# Altium schematic sheet Sch5 - Fonte 8.8V.SchDoc("Sch5 - Fonte 8.8V")
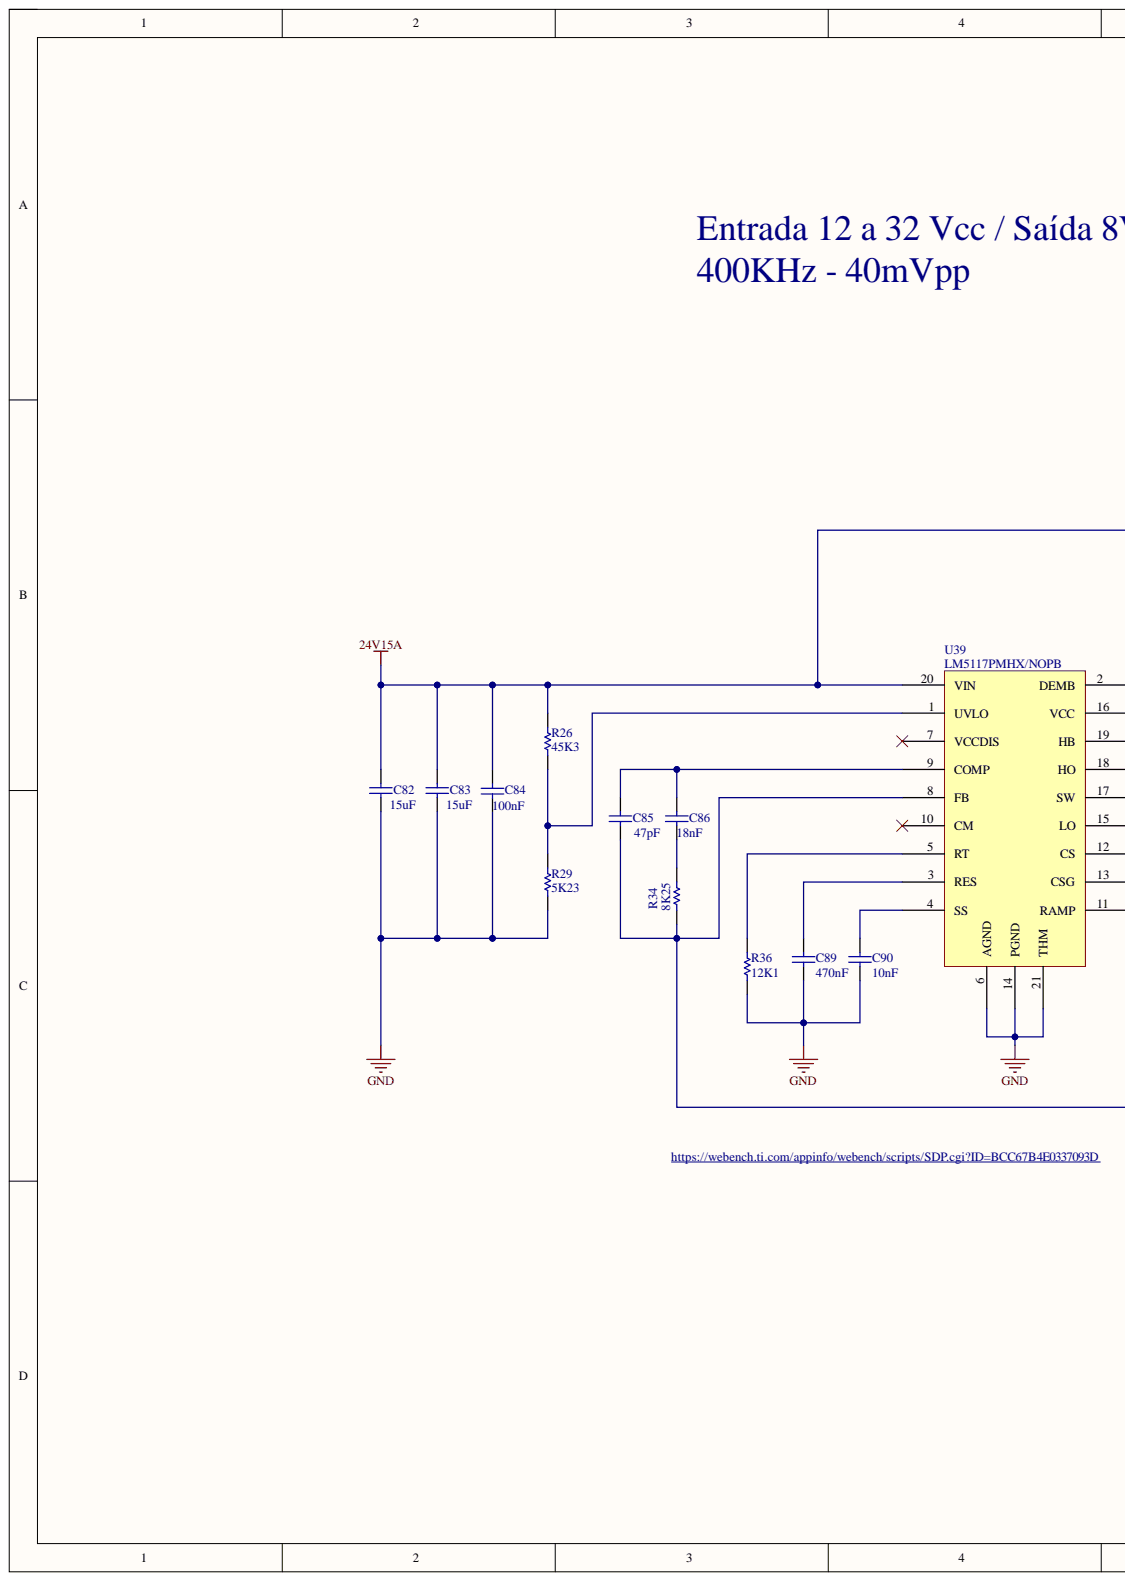
[diagram p0: Sch5 - Fonte 8.8V.SchDoc, left]
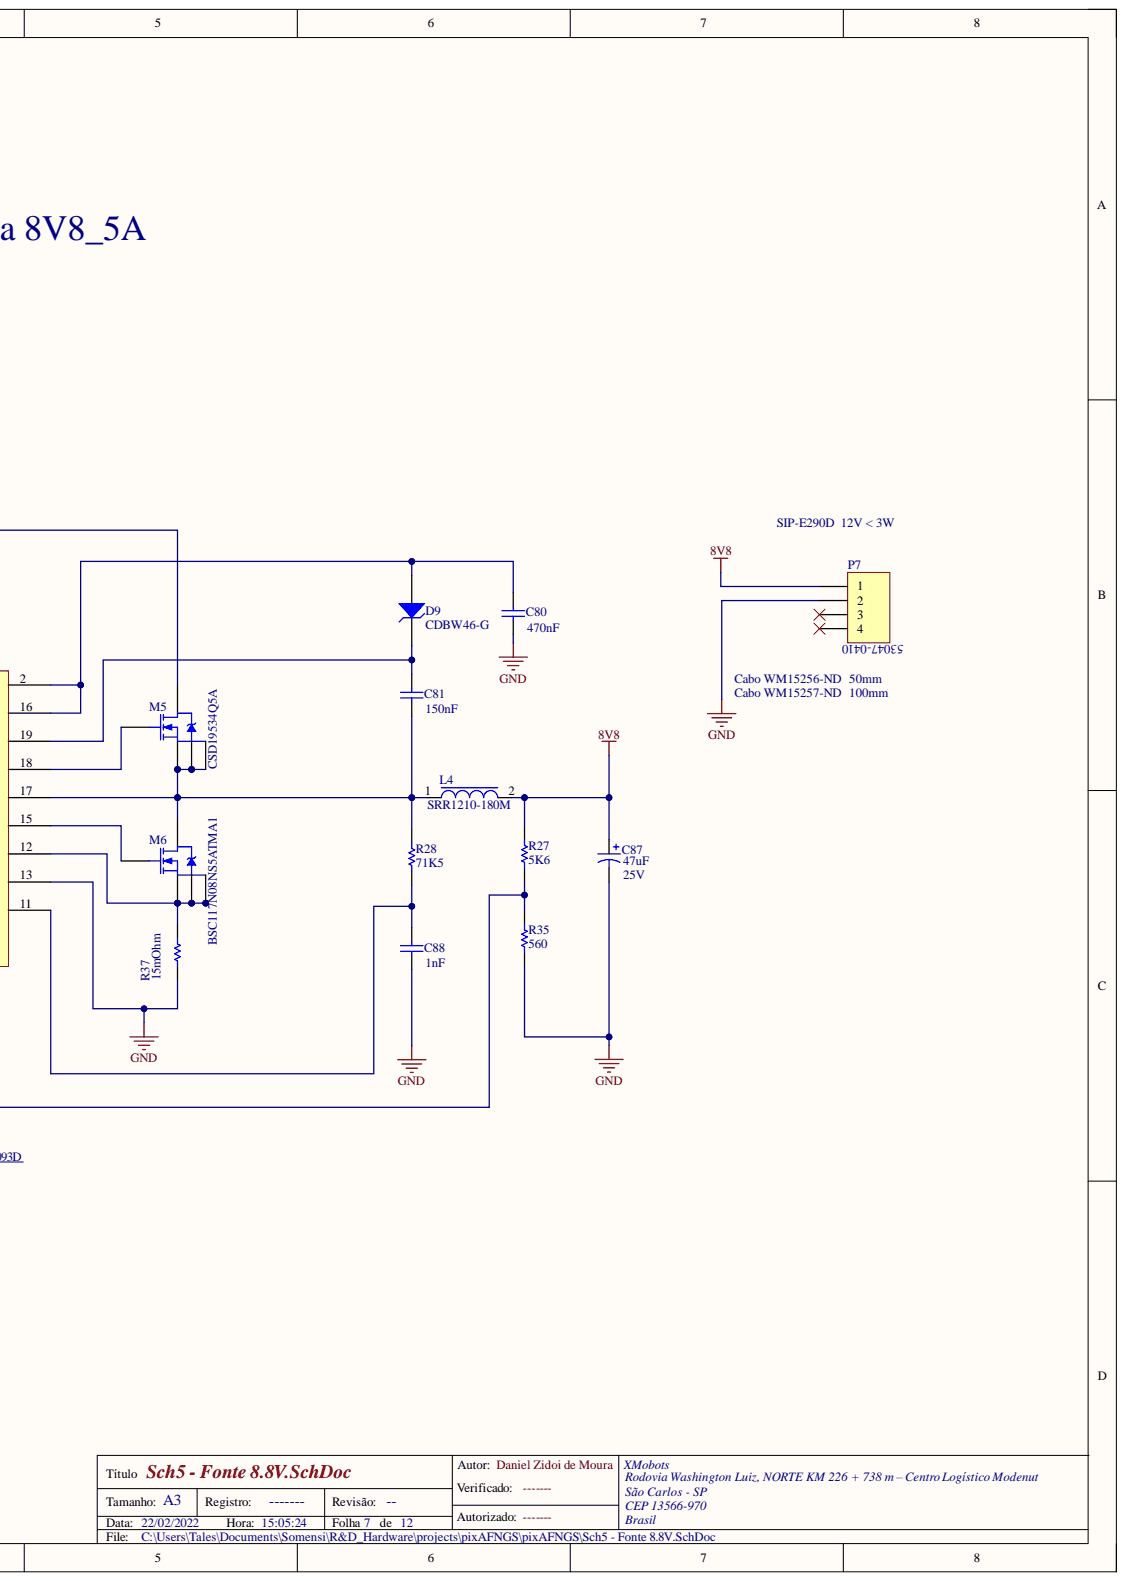
[diagram p1: Sch5 - Fonte 8.8V.SchDoc, right]

## Parts
C80  GRM188R71A474KA61D: 470nF  [0603]  (hidden comment: GRM188R71A474KA61D)
C81  GRM188R71A154KA01D: 150nF  [0603]  (hidden comment: GRM188R71A154KA01D)
C82  C5750X7S2A156M250KB: 15uF  [5750]  (hidden comment: C5750X7S2A156M250KB)
C83  C5750X7S2A156M250KB: 15uF  [5750]  (hidden comment: C5750X7S2A156M250KB)
C84  C1608X7S2A104K080AE: 100nF  [0603]  (hidden comment: C1608X7S2A104K080AE)
C85  GRM1885C1H470JA01D: 47pF  [0603]  (hidden comment: GRM1885C1H470JA01D)
C86  value 18nF  [0603]  (hidden comment: C1608C0G1V183J080AC)
C87  25SVPF47M: 47uF  Voltage: 25V  [Cap Aluminio 6,3 com furo]  (hidden comment: 25SVPF47M)
C88  C0603C821J5GACTU: 1nF  [0603]  (hidden comment: CC0603FRNPO9BN102)
C89  GRM188R71C474KA88D: 470nF  [0603]  (hidden comment: GRM188R71C474KA88D)
C90  C1608X7R1H103K080AA: 10nF  [0805]  (hidden comment: C1608X7R1H103K080AA)
D9  CDBW46-G  [SOD-123]
L4  SRR1210-180M  [SRR1210-180M]
M5  CSD19534Q5A  [TDSON_8]
M6  BSC117N08NS5ATMA1  [TDSON_8]
P7  53047-0410  [53047-0410]
R26  CRCW060354K9FKEA: 45K3  [0603]  (hidden comment: CRCW060354K9FKEA)
R27  RC0603FR-075K6L: 5K6  [0603]  (hidden comment: RC0603FR-075K6L)
R28  value 71K5  [1206]  (hidden comment: ERJ-3EKF7152V)
R29  RMCF0603FT5K23: 5K23  [0603]  (hidden comment: RMCF0603FT5K23)
R34  value 8K25  [0603]  (hidden comment: RC0603FR-078K25L)
R35  RC0603JR-07560RL  [0603]  (value not drawn)
R36  value 12K1  [0603]  (hidden comment: RC0603FR-0712K1L)
R37  value 15mOhm  [1206]  (hidden comment: ERJ-8BWFR015V)
U39  LM5117PMHX/NOPB  [HTSSOP-20]

## Nets
8V8: C87.1 L4.2 P7.1 R27.1
24V15A: C82.2 C83.2 C84.2 M5.5 R26.2 U39.20(VIN)
GND: C80.1 C82.1 C83.1 C84.1 C87.2 C88.1 C89.1 C90.2 P7.2 R29.1 R35.2 R36.1 R37.1 U39.6(AGND) U39.13(CSG) U39.14(PGND) U39.21(THM)
NetC80_2: C80.2 D9.1 U39.2(DEMB) U39.16(VCC)
NetC81_1: C81.1 L4.1 M5.1 M5.2 M5.3 M6.5 R28.2 U39.17(SW)
NetC81_2: C81.2 D9.2 U39.19(HB)
NetC85_1: C85.1 R27.2 R34.1 R35.1 U39.8(FB)
NetC85_2: C85.2 C86.2 U39.9(COMP)
NetC86_1: C86.1 R34.2
NetC88_2: C88.2 R28.1 U39.11(RAMP)
NetC89_2: C89.2 U39.3(RES)
NetC90_1: C90.1 U39.4(SS)
NetM5_4: M5.4 U39.18(HO)
NetM6_1: M6.1 M6.2 M6.3 R37.2 U39.12(CS)
NetM6_4: M6.4 U39.15(LO)
NetR26_1: R26.1 R29.2 U39.1(UVLO)
NetR36_2: R36.2 U39.5(RT)

Not connected: P7 3 4; U39 7(VCCDIS) 10(CM)

## Library data of the parts
C80-C85 C88-C90 = Cap Semi: Capacitor (Semiconductor SIM Model); Component Kind: Standard; Library Name: Miscellaneous Devices.IntLib; PackageReference: C1206; Published: 8-Jun-2000; Signal Integrity: Cap Semi; Simulation: CAP; Publisher: Altium Limited
  C80 C81 C85 C88-C90: LatestRevisionDate: 17-Jul-2002; LatestRevisionNote: Re-released for DXP Platform.
  C82-C84: LatestRevisionDate: 29-May-2009; LatestRevisionNote: IPC-7351 Footprint Added.; PackageDescription: Chip Capacitor
C86 = Cap Semi: Capacitor (Semiconductor SIM Model); Part Number: CL10C332JB8NFNC; Component Kind: Standard; LatestRevisionDate: 17-Jul-2002; LatestRevisionNote: Re-released for DXP Platform.; Library Name: Miscellaneous Devices.IntLib; PackageReference: C1206; Published: 8-Jun-2000; Signal Integrity: Cap Semi; Simulation: CAP; Publisher: Altium Limited
C87 = Cap Pol1: Polarized Capacitor (Radial); Component Kind: Standard; LatestRevisionDate: 17-Jul-2002; LatestRevisionNote: Re-released for DXP Platform.; Library Name: Miscellaneous Devices.IntLib; PackageReference: RB7.6-15; Published: 8-Jun-2000; Signal Integrity: Cap Pol; Simulation: CAP; Publisher: Altium Limited
D9 = BAS316-TP: DIODE 100V 500MA SOD323; Component Kind: Standard; Supplier 1: Digi-Key; Supplier Part Number 1: BAS316-TPMSCT-ND; Library Name: XM_Diodes.SchLib
L4 = 74435588200: FIXED IND 18UH 5.5A 35 MOHM SMD; Component Kind: Standard; Supplier 1: Digi-Key; Supplier Part Number 1: 732-4241-1-ND; Library Name: XM_Inductor.SchLib
M5 M6 = BSC117N08NS5ATMA1: MOSFET N-CH 80V 49A Automotive; Component Kind: Standard; Library Name: XM_Fet.SchLib
P7 = Header 4H: Header, 4-Pin, Right Angle; Component Kind: Standard; LatestRevisionDate: 17-Jul-2002; LatestRevisionNote: Re-released for DXP Platform.; Library Name: Miscellaneous Connectors.IntLib; Signal Integrity: Connector; Publisher: Altium Limited
R26 R27 R29 R36 = Res Semi: Semiconductor Resistor; Component Kind: Standard; LatestRevisionDate: 17-Jul-2002; LatestRevisionNote: Re-released for DXP Platform.; Library Name: Miscellaneous Devices.IntLib; PackageReference: AXIAL-0.5; Published: 8-Jun-2000; Signal Integrity: Res Semi; Simulation: RES; Publisher: Altium Limited
R28 = Res Semi: Semiconductor Resistor; Part Number: CRCW120628K0FKEA; Component Kind: Standard; LatestRevisionDate: 17-Jul-2002; LatestRevisionNote: Re-released for DXP Platform.; Library Name: Miscellaneous Devices.IntLib; PackageReference: AXIAL-0.5; Published: 8-Jun-2000; Signal Integrity: Res Semi; Simulation: RES; Publisher: Altium Limited
R34 = Res Semi: Semiconductor Resistor; Part Number: RC0603FR-0710K2L; Component Kind: Standard; LatestRevisionDate: 17-Jul-2002; LatestRevisionNote: Re-released for DXP Platform.; Library Name: Miscellaneous Devices.IntLib; PackageReference: AXIAL-0.5; Published: 8-Jun-2000; Signal Integrity: Res Semi; Simulation: RES; Publisher: Altium Limited
R35 = Res Semi: Semiconductor Resistor; RC0603JR-07560RL: 560; Component Kind: Standard; LatestRevisionDate: 17-Jul-2002; LatestRevisionNote: Re-released for DXP Platform.; Library Name: Miscellaneous Devices.IntLib; PackageReference: AXIAL-0.5; Published: 8-Jun-2000; Signal Integrity: Res Semi; Simulation: RES; Publisher: Altium Limited
R37 = Res Semi: Semiconductor Resistor; Part Number: WSLP12068L000FEA; Component Kind: Standard; LatestRevisionDate: 17-Jul-2002; LatestRevisionNote: Re-released for DXP Platform.; Library Name: Miscellaneous Devices.IntLib; PackageReference: AXIAL-0.5; Published: 8-Jun-2000; Signal Integrity: Res Semi; Simulation: RES; Publisher: Altium Limited
U39 = LM5117PMHX/NOPB: Component Kind: Standard; Library Name: XM_IC.SchLib
